# Altium schematic sheet PSDT Power v1.0.0.SchDoc("PSDT Power v1.0.0")
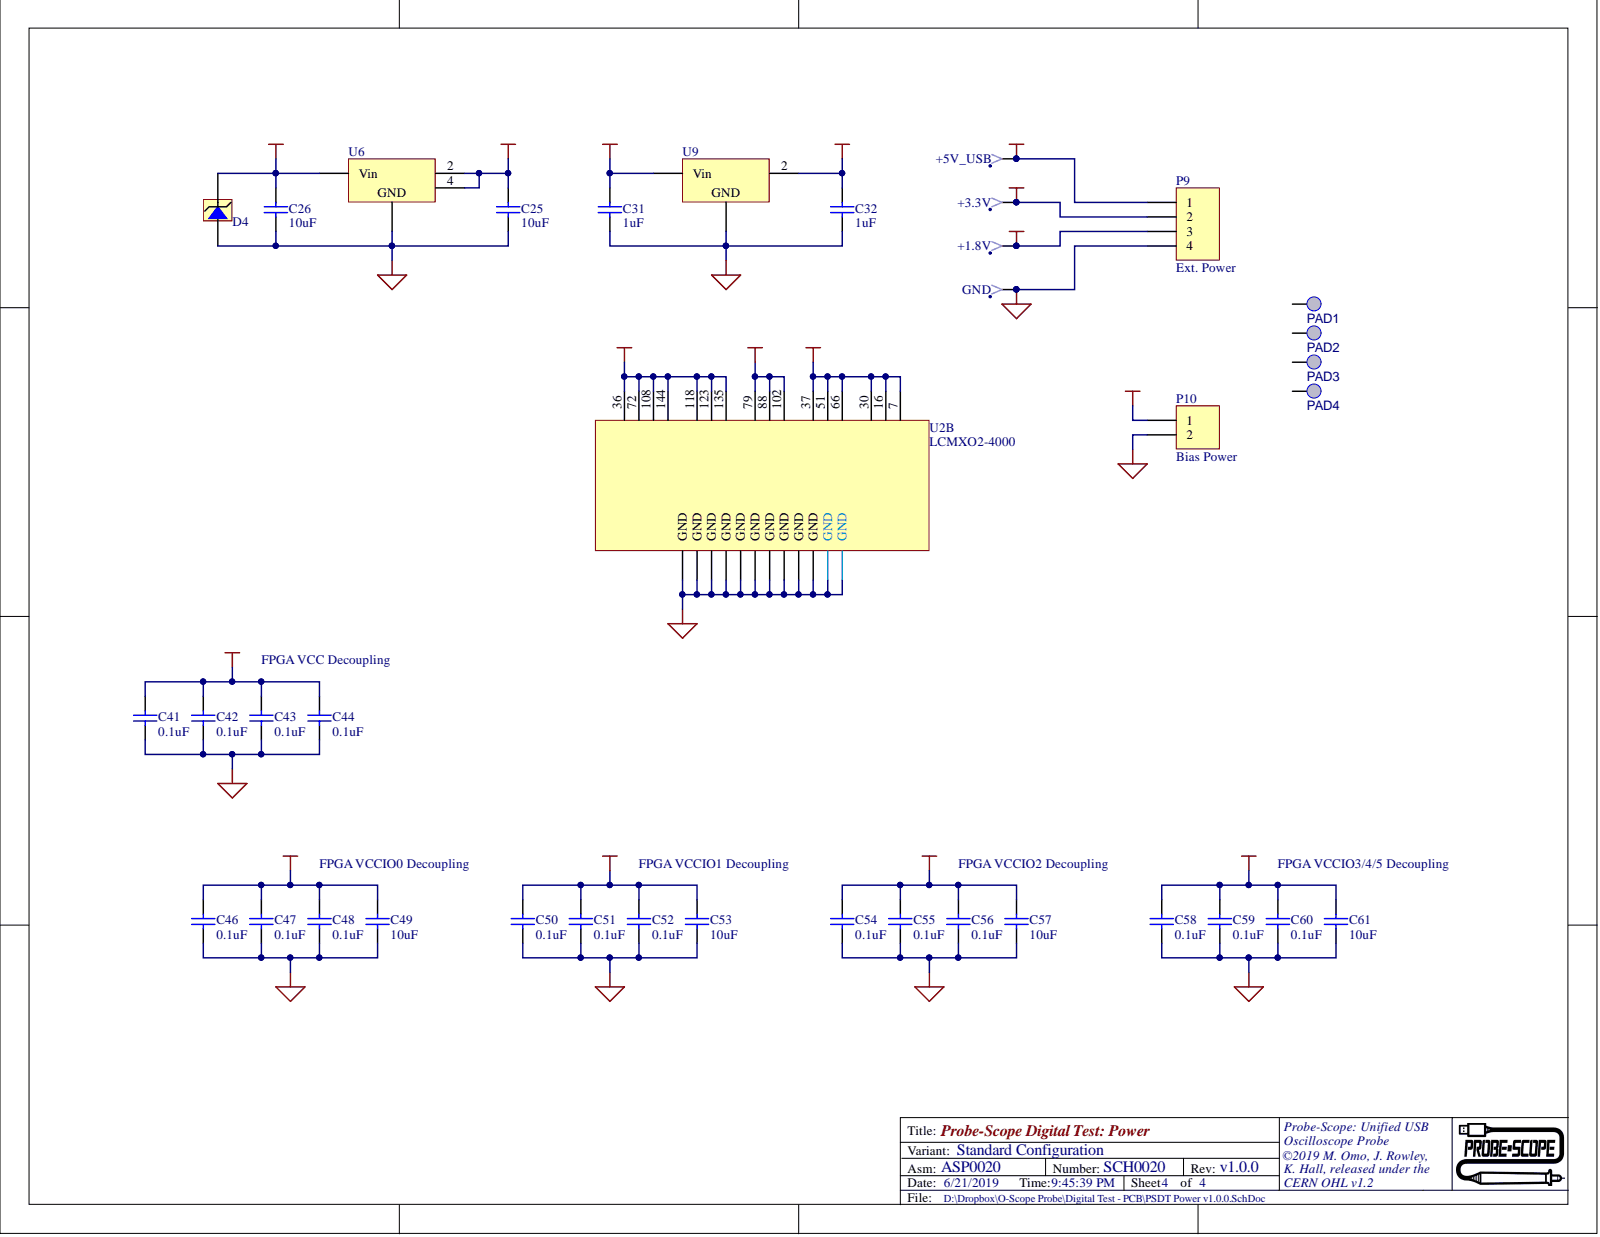
[diagram p0: PSDT Power v1.0.0.SchDoc]

## Parts
+1.8V  TP  [TESTPOINT024]  (value not drawn)
+3.3V  TP  [TESTPOINT024]  (value not drawn)
+5V_USB  TP  [TESTPOINT024]  (value not drawn)
C25  value 10uF  [0603(1608)]  (hidden comment: 25V)
C26  value 10uF  [0603(1608)]  (hidden comment: 25V)
C31  value 1uF  [0402(1005)]  (hidden comment: 10V)
C32  value 1uF  [0402(1005)]  (hidden comment: 10V)
C41  value 0.1uF  [0402(1005)]  (hidden comment: 6.3V)
C42  value 0.1uF  [0402(1005)]  (hidden comment: 6.3V)
C43  value 0.1uF  [0402(1005)]  (hidden comment: 6.3V)
C44  value 0.1uF  [0402(1005)]  (hidden comment: 6.3V)
C46  value 0.1uF  [0402(1005)]  (hidden comment: 6.3V)
C47  value 0.1uF  [0402(1005)]  (hidden comment: 6.3V)
C48  value 0.1uF  [0402(1005)]  (hidden comment: 6.3V)
C49  value 10uF  [0603(1608)]  (hidden comment: 25V)
C50  value 0.1uF  [0402(1005)]  (hidden comment: 6.3V)
C51  value 0.1uF  [0402(1005)]  (hidden comment: 6.3V)
C52  value 0.1uF  [0402(1005)]  (hidden comment: 6.3V)
C53  value 10uF  [0603(1608)]  (hidden comment: 25V)
C54  value 0.1uF  [0402(1005)]  (hidden comment: 6.3V)
C55  value 0.1uF  [0402(1005)]  (hidden comment: 6.3V)
C56  value 0.1uF  [0402(1005)]  (hidden comment: 6.3V)
C57  value 10uF  [0603(1608)]  (hidden comment: 25V)
C58  value 0.1uF  [0402(1005)]  (hidden comment: 6.3V)
C59  value 0.1uF  [0402(1005)]  (hidden comment: 6.3V)
C60  value 0.1uF  [0402(1005)]  (hidden comment: 6.3V)
C61  value 10uF  [0603(1608)]  (hidden comment: 25V)
D4  6.5V  [SMB NP]  (value not drawn)
GND  TP  [TESTPOINT024]  (value not drawn)
P9  Ext. Power  [0.1HDR1X4P]
P10  Bias Power  [0.1HDR1X2P]
PAD1  4-40 Loose  [PAD-4-40 Loose]  (value not drawn)  (no BOM)
PAD2  4-40 Loose  [PAD-4-40 Loose]  (value not drawn)  (no BOM)
PAD3  4-40 Loose  [PAD-4-40 Loose]  (value not drawn)  (no BOM)
PAD4  4-40 Loose  [PAD-4-40 Loose]  (value not drawn)  (no BOM)
U2  LCMXO2-4000  [UL_TQFP144]  (part B)
U6  LD1086D2M33TR  [D2PAK TO263-4N]  (value not drawn)
U9  1.8V  [SOT23-12-3TOP]  (value not drawn)

## Nets
+1.8V: +1.8V.1 C32.2 C50.2 C51.2 C52.2 C53.2 P9.3 U2.79 U2.88 U2.102 U9.2
+3.3V: +3.3V.1 C25.2 C31.2 C41.2 C42.2 C43.2 C44.2 C46.2 C47.2 C48.2 C49.2 C54.2 C55.2 C56.2 C57.2 C58.2 C59.2 C60.2 C61.2 P9.2 P10.1 U2.7 U2.16 U2.30 U2.36 U2.37 U2.51 U2.66 U2.72 U2.108 U2.118 U2.123 U2.135 U2.144 U6.2 U6.4 U9.3
+5V_USB: +5V_USB.1 C26.2 D4.2 P9.1 U6.3(Vin)
GND: C25.1 C26.1 C31.1 C32.1 C41.1 C42.1 C43.1 C44.1 C46.1 C47.1 C48.1 C49.1 C50.1 C51.1 C52.1 C53.1 C54.1 C55.1 C56.1 C57.1 C58.1 C59.1 C60.1 C61.1 D4.1 GND.1 P9.4 P10.2 U2.8(GND) U2.18(GND) U2.29(GND) U2.46(GND) U2.53(GND) U2.64(GND) U2.80(GND) U2.90(GND) U2.101(GND) U2.116(GND) U2.124(GND) U2.134(GND) U6.1 U9.1

Not connected: PAD1 1; PAD2 1; PAD3 1; PAD4 1

## Library data of the parts
+1.8V +3.3V +5V_USB GND = 49: VENDOR_PN: 1998-3K-ND; VENDOR: Digikey; Sex: Male; Positions: 1; P&V Description: Connector, Test Point, 1 Positions, Male, Small, 0.27" Straight Pin, 0.02" dia; Other: Small, 0.27" Straight Pin, 0.02" dia; MENG_PN: CON00002; MANF_PN: 1998-3; MANF: Keystone Electronics; Database Table Name: Connectors; Class: Connector; Approved: True; Verified: True; Type: Test Point; SMD: False; Signal Integrity: Connector; Parent Key: 49; Key: 49; Date Modified: 9/30/2014 10:31:54 AM; Component Kind: Standard; Modified By: sotterbacher; Library Name: MENG Database Library VPN.DbLib
C25 C26 C49 C53 C57 C61 = 1306: Voltage: 25; VENDOR_PN: 1276-1869-1-ND; VENDOR: Digikey; Type: Ceramic; Tolerance: 20; P&V Description: Capacitor, Ceramic, 10uF, 25V, 20% Tol, 85C, X5R, 0603, SMD; Other: X5R; MENG_PN: ???1306; Max Temperature: 85; MANF_PN: CL10A106MA8NRNC; MANF: Samsung Electro-Mechanics; Database Table Name: Capacitors; Class: Capacitor; Approved: True; Verified: True; SMD: True; Simulation: CAP; Signal Integrity: Cap; Parent Key: 1306; Package: 0603; Key: 1306; Date Modified: 3/3/2015 11:08:29 AM; Component Kind: Standard; Modified By: sotterbacher; Library Name: MENG Database Library VPN.DbLib
C31 C32 = 1876: Voltage: 10; VENDOR_PN: 490-13409-1-ND; VENDOR: Digikey; Type: Ceramic; P&V Description: Capacitor, Ceramic, 1uF, 10V, 10% Tol, 125C, X7S, 0402, SMD; Other: X7S; MENG_PN: ???1876; Max Temperature: 125; MANF_PN: GCM155C71A105KE38D; MANF: Murata Electronics; Database Table Name: Capacitors; Class: Capacitor; Approved: False; Verified: False; Tolerance: 10; SMD: True; Simulation: CAP; Signal Integrity: Cap; Parent Key: 1876; Package: 0402; Key: 1876; Date Modified: 11/6/2018 11:19:13 AM; Component Kind: Standard; Modified By: jrowley; Library Name: MENG Database Library VPN.DbLib
C41-C44 C46-C48 C50-C52 C54-C56 C58-C60 = 1875: Voltage: 6.3; VENDOR_PN: 1276-1511-1-ND; VENDOR: Digikey; Type: Ceramic; Tolerance: 10; P&V Description: Capacitor, Ceramic, 0.1uF, 6.3V, 10% Tol, 125C, X7R, 0402, SMD; Other: X7R; MENG_PN: ???1875; Max Temperature: 125; MANF_PN: CL05B104KQ5NNNC; MANF: Samsung Electro-Mechanics; Database Table Name: Capacitors; Class: Capacitor; Approved: False; Verified: False; SMD: True; Simulation: CAP; Signal Integrity: Cap; Parent Key: 1875; Package: 0402; Key: 1875; Date Modified: 11/6/2018 11:14:02 AM; Component Kind: Standard; Modified By: jrowley; Library Name: MENG Database Library VPN.DbLib
D4 = 1447: Voltage: 6.5; VENDOR_PN: SMBJ6.5ALFCT-ND; VENDOR: Digikey; Type: Transzorb; Power: 600; P&V Description: Diode, Transzorb, 6.5V, 53.6A, 600W, V breakdoen min 7.22V, Voltage Clamping Max 11.2V, Unidirectional Zener Clamp, TVS, Circuit Protection, SMB, SMD; Other: V breakdoen min 7.22V, Voltage Clamping Max 11.2V, Unidirectional Zener Clamp, TVS, Circuit Protection; MENG_PN: ???1447; MANF_PN: SMBJ6.5A; MANF: Littelfuse; Database Table Name: Diodes and Passive Semiconductors; Current: 53.6; Class: Diode; Approved: True; Verified: True; SMD: True; Parent Key: 1447; Package: SMB; Key: 1447; Date Modified: 4/13/2016 2:45:26 PM; Component Kind: Standard; Modified By: tgage; Library Name: MENG Database Library VPN.DbLib
P9 = 163: Voltage: 250; VENDOR_PN: WM2702-ND; VENDOR: Digikey; Spacing: 0.1; Sex: Male; Positions: 4; P&V Description: Connector, Header, 0.1", 4 Positions, Male, 0.1" Spacing, 4A, 250V, 0.24" Long, 0.125" Tail, 15u" Select Gold, Polarized, Locking Ramp, Vert; Other: 0.24" Long, 0.125" Tail, 15u" Select Gold, Polarized, Locking Ramp, Vert; MENG_PN: CON00029; MANF: Molex; Database Table Name: Connectors; Class: Connector; Approved: False; Verified: False; Type: Header, 0.1"; SMD: False; Signal Integrity: Connector; Parent Key: 163; MANF_PN: 22-11-2042; Key: 163; Date Modified: 7/21/2017 2:27:52 PM; Current: 4; Component Kind: Standard; Modified By: jrowley; Library Name: MENG Database Library VPN.DbLib
P10 = 375: Voltage: 250; VENDOR_PN: WM2700-ND; VENDOR: Digikey; Spacing: 0.1; Sex: Male; Positions: 2; P&V Description: Connector, Header, 0.1", 2 Positions, Male, 0.1" Spacing, 4A, 250V, 0.24" Long, 0.125" Tail, 15u Select Gold, Polarized, Locking Ramp; Other: 0.24" Long, 0.125" Tail, 15u Select Gold, Polarized, Locking Ramp; MENG_PN: CON00068; MANF: Molex; Database Table Name: Connectors; Current: 4; Class: Connector; Approved: False; Verified: False; Type: Header, 0.1"; SMD: False; Signal Integrity: Connector; Parent Key: 375; MANF_PN: 22-11-2022; Key: 375; Date Modified: 7/21/2017 2:28:28 PM; Component Kind: Standard; Modified By: jrowley; Library Name: MENG Database Library VPN.DbLib
PAD1-PAD4 = 671: VENDOR: dummy; P&V Description: Fabrication, Hole, 4-40 Pad, Loose Fit, Not a Part; Other: 4-40 Pad, Loose Fit, Not a Part; MENG_PN: ???671; MANF_PN: 000-671; Database Table Name: Mechanical And Assembly; Class: Fabrication; Approved: True; Verified: True; Type: Hole; SMD: False; Parent Key: 671; MANF: dummy; Key: 671; Date Modified: 11/19/2010 2:33:14 PM; Modified By: pmarcus; Library Name: MENG Database Library VPN.DbLib
U2 = MachXO2-4000-TG144: VENDOR_PN: 220-1460-ND; VENDOR: DigiKey; MANF_PN: LCMXO2-4000HC-4TG144C; MANF: Lattice Semiconductor Corporation; Library Name: PS.SchLib; Component Kind: Standard
U6 = 690: VENDOR_PN: 497-1223-1-ND; VENDOR: Digikey; P&V Description: IC, Voltage Regulator, 3.3V, 1.5A max Iout, 30V Max Vin, DPAK-3, SMD; Other: 3.3V, 1.5A max Iout, 30V Max Vin; MENG_PN: ANG00046; MANF: ST Microelectronics; Database Table Name: Analog and Mixed ICs; Class: IC; Approved: True; Verified: True; Type: Voltage Regulator; SMD: True; Parent Key: 690; Package: DPAK-3; MANF_PN: LD1086D2M33TR; Key: 690; Date Modified: 4/8/2011 3:28:12 PM; Component Kind: Standard; Modified By: cschneiker; Library Name: MENG Database Library VPN.DbLib
U9 = 2073: VENDOR_PN: MCP1703T-1802E/CBCT-ND; VENDOR: Digikey; P&V Description: IC, Voltage Regulator, 1.8Vout, 2.7-16Vin, 200mA, -40°C ~ 125°C, SOT-23, SMD; Other: 1.8Vout, 2.7-16Vin, 200mA, -40°C ~ 125°C; MENG_PN: ???2073; MANF_PN: MCP1703T-1802E/CB; MANF: Microchip; Database Table Name: Analog and Mixed ICs; Class: IC; Approved: False; Verified: False; Type: Voltage Regulator; SMD: True; Parent Key: 2073; Package: SOT-23; Key: 2073; Date Modified: 6/21/2019 8:23:33 PM; Component Kind: Standard; Modified By: jrowley; Library Name: MENG Database Library VPN.DbLib
